# Altium schematic sheet Log and Anti-Log Converters 1.SchDoc
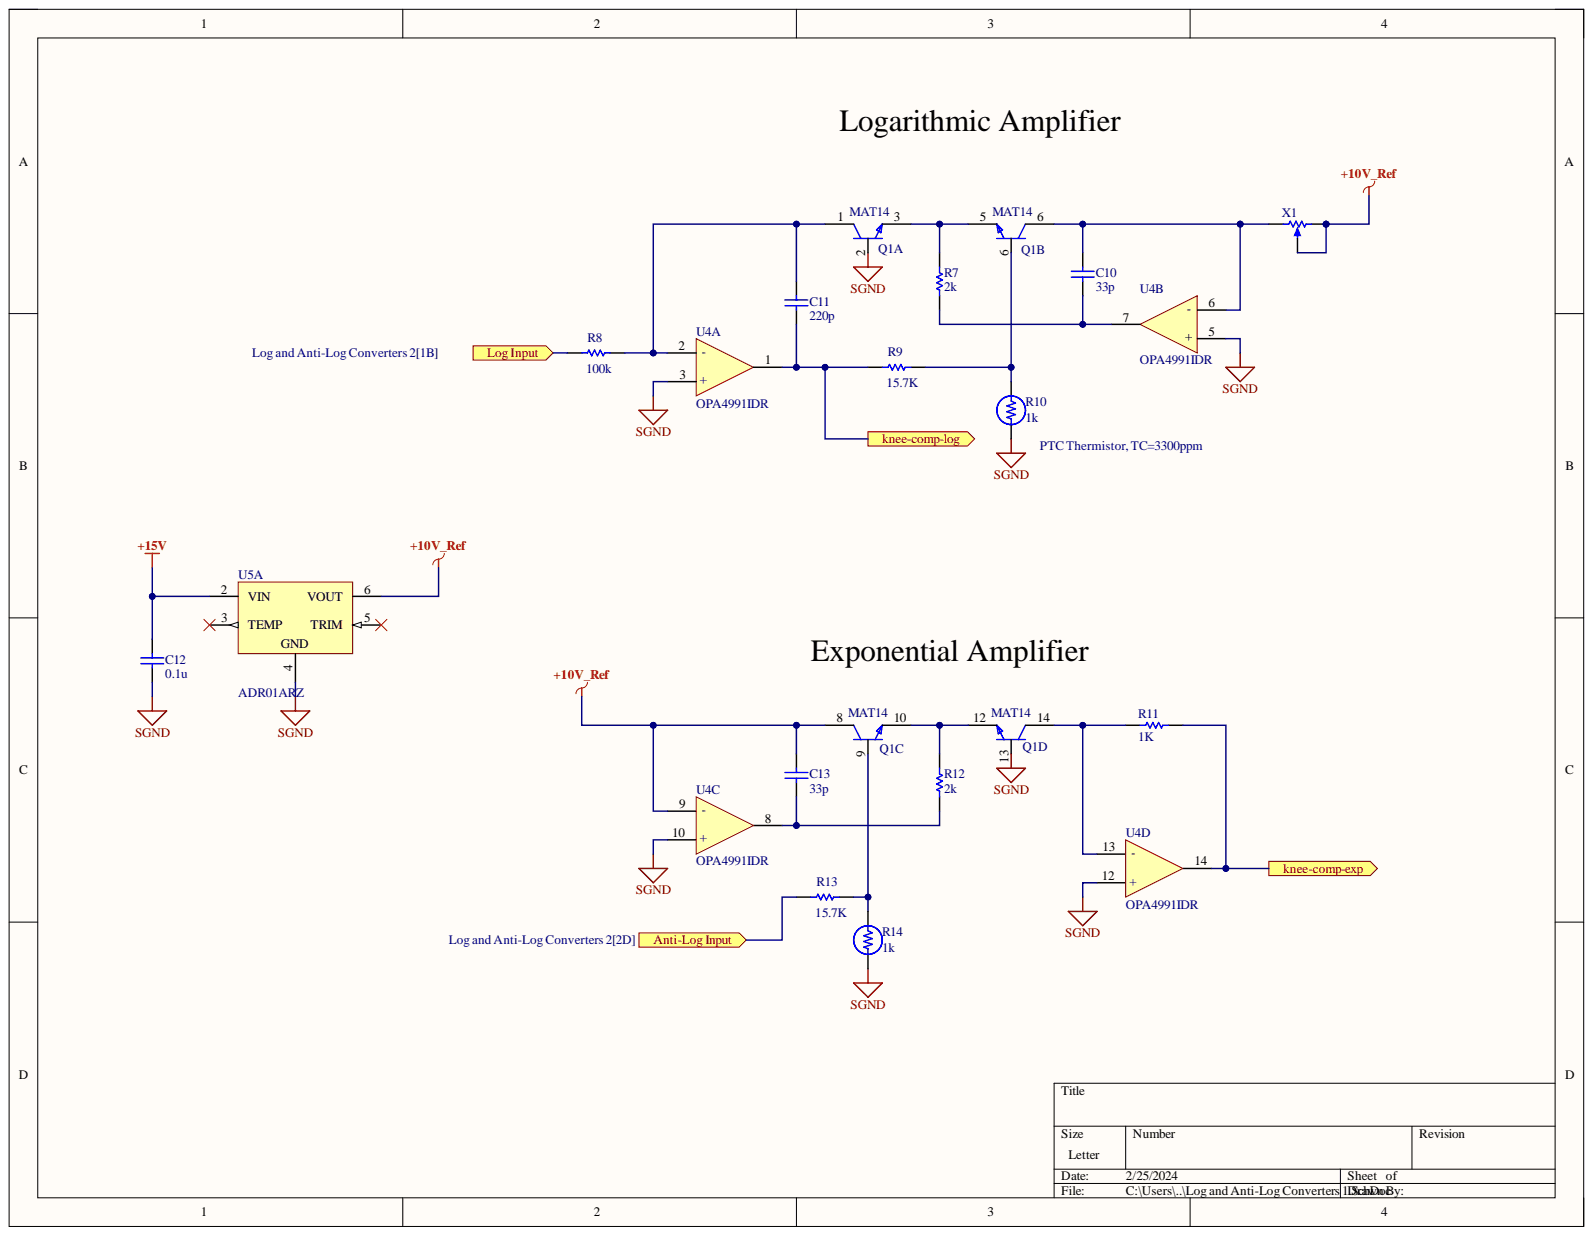
[diagram p0: Log and Anti-Log Converters 1.SchDoc]

## Parts
C10  value 33p  (hidden comment: Capacitor)
C11  value 220p  (hidden comment: Capacitor)
C12  value 0.1u  (hidden comment: Capacitor)
C13  value 33p  (hidden comment: Capacitor)
Q1  MAT14  [FP-R-14-IPC_B]  (parts A,B,C,D)
R7  value 2k  (hidden comment: Resistor)
R8  value 100k  (hidden comment: Resistor)
R9  value 15.7K  (hidden comment: Resistor)
R10  1k
R11  value 1K  (hidden comment: Resistor)
R12  value 2k  (hidden comment: Resistor)
R13  value 15.7K  (hidden comment: Resistor)
R14  1k
U4  OPA4991IDR  [FP-D0014A-IPC_B]  (parts A,B,C,D)
U5  ADR01ARZ  [ADI-R-8_M]  (part A)
X1  Potentiometer  (value not drawn)

## Ports
Anti-Log Input, knee-comp-exp, knee-comp-log, Log Input

## Nets
+10V_Ref: C13.2 Q1.8 U4.9(-) U5.6(VOUT) X1.2 X1.3
+15V: C12.2 U5.2(VIN)
SGND: C12.1 Q1.2 Q1.13 R10.1 R14.1 U4.3(+) U4.5(+) U4.10(+) U4.12(+) U5.4
Anti-Log Input: R13.2 ; port Anti-Log Input
knee-comp-exp: R11.2 U4.14 ; port knee-comp-exp
knee-comp-log: C11.1 R9.2 U4.1 ; port knee-comp-log
Log Input: R8.2 ; port Log Input
NetC10_1: C10.1 R7.1 U4.7
NetC10_2: C10.2 Q1.6 U4.6(-) X1.1
NetC11_2: C11.2 Q1.1 R8.1 U4.2(-)
NetC13_1: C13.1 R12.1 U4.8
NetQ1_3: Q1.3 Q1.5 R7.2
NetQ1_6: Q1.6 R9.1 R10.2
NetQ1_9: Q1.9 R13.1 R14.2
NetQ1_10: Q1.10 Q1.12 R12.2
NetQ1_14: Q1.14 R11.1 U4.13(-)

Not connected: U5 3(TEMP) 5(TRIM)

## Library data of the parts
C10-C13 = Capacitor: SimulationGenericComponent: True; Component Kind: Standard; Description: Capacitor; Library Name: Simulation Generic Components.IntLib; Simulation: C_Capacitor_Default
Q1 = MAT14ARZ: TRANS 4NPN 40V 0.03A 14SO; Collector Emitter Saturation Voltage: 60mV; Frequency: 300MHz; Max Collector Current: 30mA; Max Frequency: 1kHz; Number of Elements: 4; Polarity: NPN; Case/Package: SOIC; Collector Base Voltage (VCBO): 40V; Collector Emitter Breakdown Voltage: 40V; Collector Emitter Voltage (VCEO): 40V; Collector-emitter Voltage-Max: 60mV; Component Kind: Standard; Height: 1.5mm; Lead Free: Contains Lead; Length: 8.75mm; Max Operating Temperature: 85°C; Min Operating Temperature: -40°C; Mount: Surface Mount; Number of Pins: 14; Package Quantity: 56; Packaging: Rail/Tube; Radiation Hardening: No; REACH SVHC: No SVHC; RoHS Compliant: Yes; Series: MAT14; Transition Frequency: 300MHz; Width: 4mm; Library Name: DRC.SchLib
R7-R9 R11-R13 = Resistor: SimulationGenericComponent: True; TC1: 0; Component Kind: Standard; Description: Resistor; Library Name: Simulation Generic Components.IntLib; Simulation: R_Resistor_Default; TC2: 0
R10 R14 = PTC Thermistor: Component Kind: Standard; Library Name: DRC.SchLib
U4 = OPA4991IDR: 40-V, DUAL 4.5MHZ, RAIL-TO-RAIL; Component Kind: Standard; Library Name: DRC.SchLib
U5 = CMP-0784-00317-1: Ultracompact, Precision Voltage Reference, 10 V Output, 12 to 36 V Supply, Industrial, 8-pin SOIC (R-8), Tube; Manufacturer: Analog Devices; Max V Supply (V): 36; Min V Supply (V): 12; Ref Out TC (ppm/C): 3; Vout: 10V; Code_JEDEC: MS-012-AA; Component Kind: Standard; ComponentLink1Description: Manufacturer URL; ComponentLink1URL: http://www.analog.com/en/index.html; ComponentLink2Description: Datasheet; ComponentLink2URL: http://www.analog.com/static/imported-files/data_sheets/ADR01_02_03_06.pdf; ComponentLink3Description: Package Specification; ComponentLink3URL: http://www.analog.com/static/imported-files/packages/PKG_PDF/SOIC_Narrow%20(R)/R_8.pdf; ComponentLink4Description: URL Link1; ComponentLink4URL: Product URL:http://www.analog.com/en/special-linear-functions/voltage-references/adr01/products/product.html; DatasheetVersion: Rev. R; Library Name: Altium Content Vault; Mounting Technology: Surface Mount; Operating Temp Range: -40 to 125 degC; PackageDescription: 8-Pin Standard Small Outline Package, Body 4.9 x 3.9 mm, Pitch 1.27 mm; PackageReference: R-8; PackageVersion: 060506-A, 06/2006; Packing: Tube; PartNumber: ADR01ARZ
X1 = Potentiometer: position: 0.5; SimulationGenericComponent: True; value: 1k; Component Kind: Standard; Description: Potentiometer; Library Name: Simulation Generic Components.IntLib; Simulation: POT
